# Altium schematic sheet main.SchDoc(main)
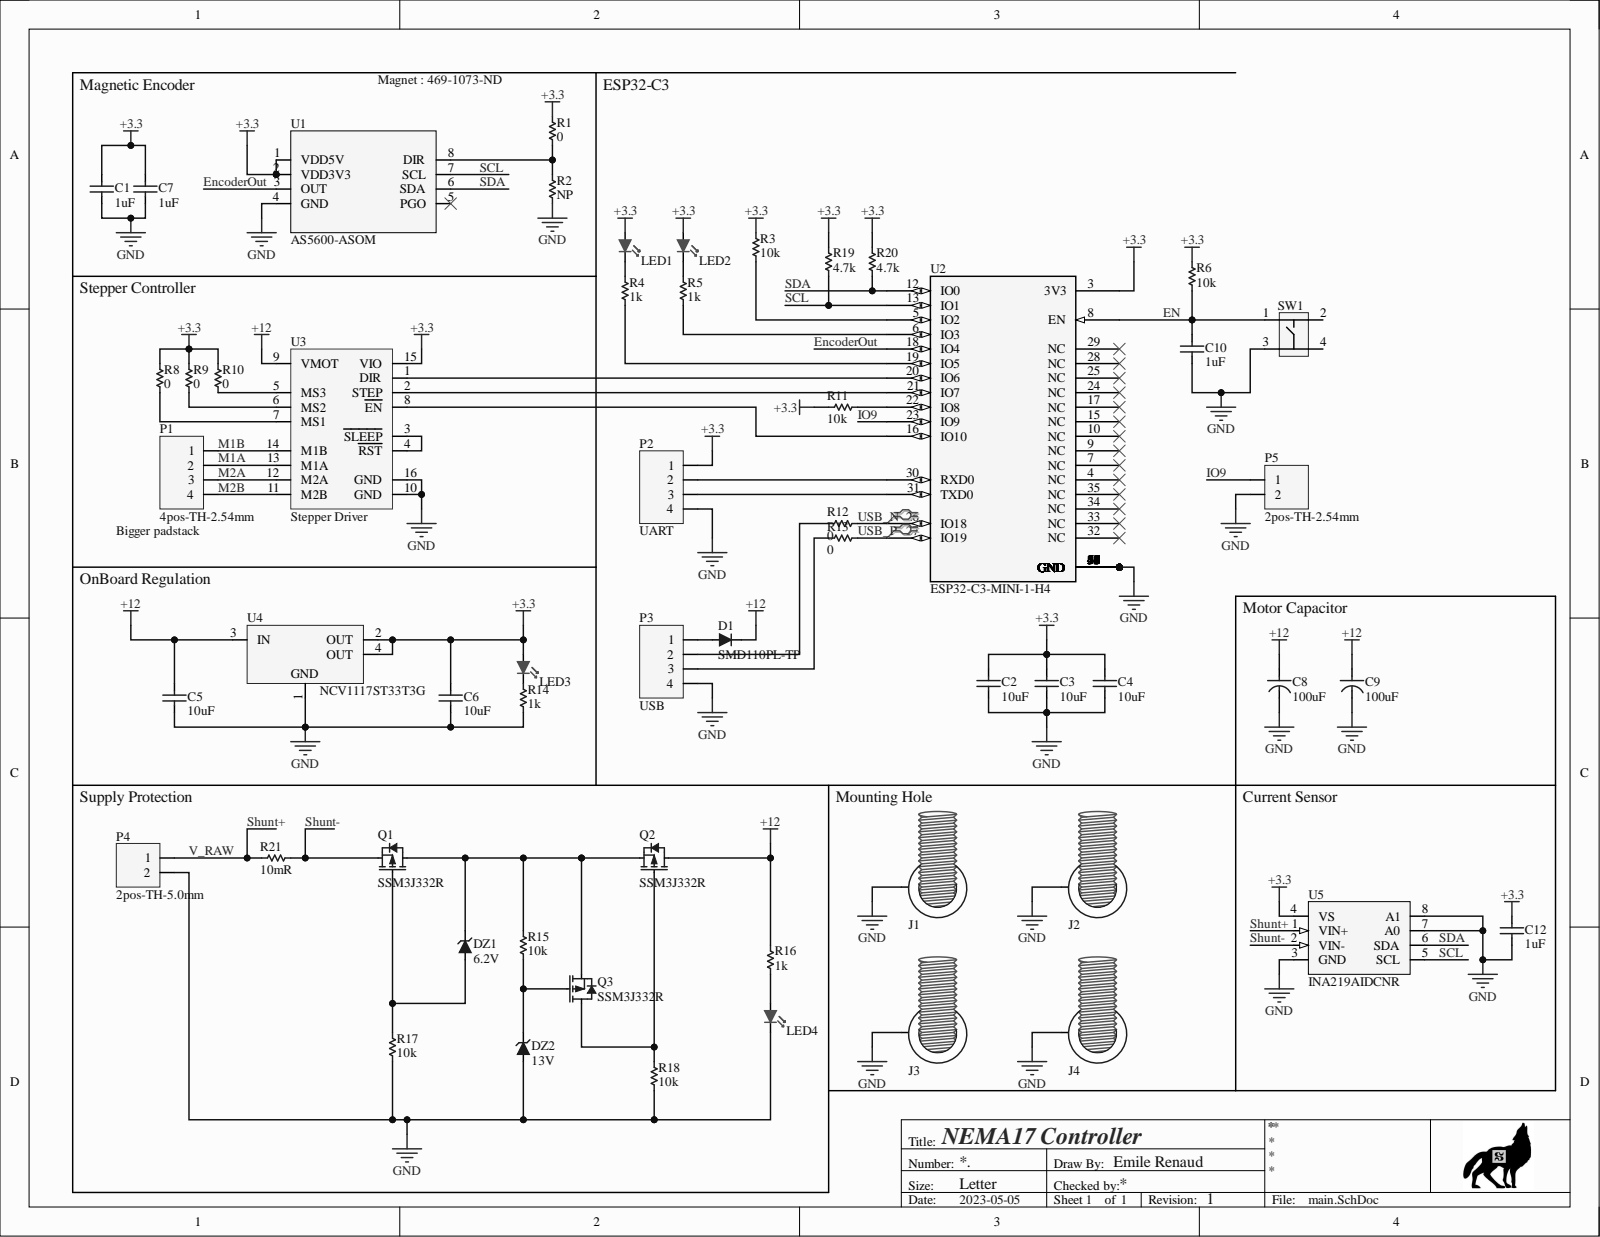
[diagram p0: main.SchDoc]

## Parts
C1  value 1uF  [CAPACITOR-0603]  (hidden comment: Capacitor-0603-1uF-25V-X5R)
C2  value 10uF  [CAPACITOR-0805]  (hidden comment: Capacitor-0805-10uF-35V-X5R)
C3  value 10uF  [CAPACITOR-0805]  (hidden comment: Capacitor-0805-10uF-35V-X5R)
C4  value 10uF  [CAPACITOR-0805]  (hidden comment: Capacitor-0805-10uF-35V-X5R)
C5  value 10uF  [CAPACITOR-0805]  (hidden comment: Capacitor-0805-10uF-35V-X5R)
C6  value 10uF  [CAPACITOR-0805]  (hidden comment: Capacitor-0805-10uF-35V-X5R)
C7  value 1uF  [CAPACITOR-0603]  (hidden comment: Capacitor-0603-1uF-25V-X5R)
C8  value 100uF  [CAPACITOR-Aluminium-5x11mm-2mmPitch]  (hidden comment: CAP ALUM 100UF 20% 25V RADIAL)
C9  value 100uF  [CAPACITOR-Aluminium-5x11mm-2mmPitch]  (hidden comment: CAP ALUM 100UF 20% 25V RADIAL)
C10  value 1uF  [CAPACITOR-0603]  (hidden comment: Capacitor-0603-1uF-25V-X5R)
C12  value 1uF  [CAPACITOR-0603]  (hidden comment: Capacitor-0603-1uF-25V-X5R)
D1  SMD110PL-TP  [SOD-123F]
DZ1  Volt: 6.2V  [SOD-123]  (hidden comment: BZT52C6V2-TP)
DZ2  Volt: 13V  [SOD-123]  (hidden comment: BZT52C13-TP)
J1  hole  [HOLE-3.40MM-M3-8MMEYELET]  (value not drawn)  (no BOM)
J2  hole  [HOLE-3.40MM-M3-8MMEYELET]  (value not drawn)  (no BOM)
J3  hole  [HOLE-3.40MM-M3-8MMEYELET]  (value not drawn)  (no BOM)
J4  hole  [HOLE-3.40MM-M3-8MMEYELET]  (value not drawn)  (no BOM)
LED1  B1911USD-20D000114U1930  [LED-0603-2PIN]  (value not drawn)
LED2  B1911USD-20D000114U1930  [LED-0603-2PIN]  (value not drawn)
LED3  B1911USD-20D000114U1930  [LED-0603-2PIN]  (value not drawn)
LED4  B1911USD-20D000114U1930  [LED-0603-2PIN]  (value not drawn)
P1  4pos-TH-2.54mm  [HDR1X4-W]
P2  UART  [HDR1X4]
P3  USB  [HDR1X4]
P4  2pos-TH-5.0mm  [Weco 2]
P5  2pos-TH-2.54mm  [HDR1X2]
Q1  SSM3J332R  [SOT-23-3]
Q2  SSM3J332R  [SOT-23-3]
Q3  SSM3J332R  [SOT-23-3]
R1  value 0  [Resistor-0603]  (hidden comment: SMT resistor)
R2  value NP  [Resistor-0603]  (hidden comment: SMT resistor)
R3  value 10k  [Resistor-0603]  (hidden comment: SMT resistor)
R4  value 1k  [Resistor-0603]  (hidden comment: SMT resistor)
R5  value 1k  [Resistor-0603]  (hidden comment: SMT resistor)
R6  value 10k  [Resistor-0603]  (hidden comment: SMT resistor)
R8  value 0  [Resistor-0603]  (hidden comment: SMT resistor)
R9  value 0  [Resistor-0603]  (hidden comment: SMT resistor)
R10  value 0  [Resistor-0603]  (hidden comment: SMT resistor)
R11  value 10k  [Resistor-0603]  (hidden comment: SMT resistor)
R12  value 0  [Resistor-0603]  (hidden comment: SMT resistor)
R13  value 0  [Resistor-0603]  (hidden comment: SMT resistor)
R14  value 1k  [Resistor-0603]  (hidden comment: SMT resistor)
R15  value 10k  [Resistor-0603]  (hidden comment: SMT resistor)
R16  value 1k  [Resistor-0603]  (hidden comment: SMT resistor)
R17  value 10k  [Resistor-0603]  (hidden comment: SMT resistor)
R18  value 10k  [Resistor-0603]  (hidden comment: SMT resistor)
R19  value 4.7k  [Resistor-0603]  (hidden comment: SMT resistor)
R20  value 4.7k  [Resistor-0603]  (hidden comment: SMT resistor)
R21  value 10mR  [RESISTOR-1206]  (hidden comment: SMT resistor)
SW1  PTS815 SJM 250 SMTR LFS  [SW4_PTS815 SJM 250 SMTR LFS_CNK]  (value not drawn)
U1  AS5600-ASOM  [AS5600L-ASOM_AMS]
U2  ESP32-C3-MINI-1-H4  [ESP32-C3-MINI-1_EXP]
U3  Stepper Driver  [A4899]
U4  NCV1117ST33T3G  [SOT-223-3/TO-261-3S]
U5  INA219AIDCNR  [SOT-23-8]

## Nets
+3.3: C1.2 C2.2 C3.2 C4.2 C6.2 C7.2 C12.2 LED1.2 LED2.2 LED3.2 P2.1 R1.2 R3.2 R6.2 R8.2 R9.2 R10.2 R11.2 R19.2 R20.2 U1.1(VDD5V) U1.2(VDD3V3) U2.3(3V3) U3.15(VIO) U4.2(OUT) U4.4(OUT) U5.4(VS)
+12: C5.2 C8.1 C9.1 D1.2 Q2.3 R16.2 U3.9(VMOT) U4.3(IN)
GND: C1.1 C2.1 C3.1 C4.1 C5.1 C6.1 C7.1 C8.2 C9.2 C10.1 C12.1 DZ2.1 J1.1 J2.1 J3.1 J4.1 LED4.1 P2.4 P3.4 P4.2 P5.2 R2.1 R14.1 R17.1 R18.1 SW1.3 U1.4(GND) U2.1(GND) U2.2 U2.11 U2.14 U2.36 U2.37 U2.38 U2.39 U2.40 U2.41 U2.42 U2.43 U2.44 U2.45 U2.46 U2.47 U2.48 U2.49 U2.50 U2.51 U2.52 U2.53 U2.54 U2.55 U2.56 U2.57 U2.58 U2.59 U2.60 U2.61 U3.10(GND) U3.16(GND) U4.1 U5.3(GND) U5.7(A0) U5.8(A1)
EN: C10.2 R6.1 SW1.1 U2.8(EN)
EncoderOut: U1.3(OUT) U2.18(IO4)
IO9: P5.1 U2.23(IO9)
M1A: P1.2 U3.13(M1A)
M1B: P1.1 U3.14(M1B)
M2A: P1.3 U3.12(M2A)
M2B: P1.4 U3.11(M2B)
SCL: R19.1 U1.7(SCL) U2.13(IO1) U5.5(SCL)
SDA: R20.1 U1.6(SDA) U2.12(IO0) U5.6(SDA)
Shunt+: P4.1 R21.1 U5.1(VIN+)
Shunt-: Q1.2 R21.2 U5.2(VIN-)
USB_N: R12.2 U2.26(IO18)
USB_P: R13.2 U2.27(IO19)
NetD1_1: D1.1 P3.1
NetDZ1_1: DZ1.1 Q1.1 R17.2
NetDZ1_2: DZ1.2 Q1.3 Q2.2 Q3.2 R15.2
NetDZ2_2: DZ2.2 Q3.1 R15.1
NetLED1_1: LED1.1 R4.2
NetLED2_1: LED2.1 R5.2
NetLED3_1: LED3.1 R14.2
NetLED4_2: LED4.2 R16.1
NetP2_2: P2.2 U2.30(RXD0)
NetP2_3: P2.3 U2.31(TXD0)
NetP3_2: P3.2 R12.1
NetP3_3: P3.3 R13.1
NetQ2_1: Q2.1 Q3.3 R18.2
NetR1_1: R1.1 R2.2 U1.8(DIR)
NetR3_1: R3.1 U2.5(IO2)
NetR4_1: R4.1 U2.19(IO5)
NetR5_1: R5.1 U2.6(IO3)
NetR8_1: R8.1 U3.7(MS1)
NetR9_1: R9.1 U3.6(MS2)
NetR10_1: R10.1 U3.5(MS3)
NetR11_1: R11.1 U2.22(IO8)
NetU2_16: U2.16(IO10) U3.8(EN)
NetU2_20: U2.20(IO6) U3.1(DIR)
NetU2_21: U2.21(IO7) U3.2(STEP)
NetU3_3: U3.3(SLEEP) U3.4(RST)

Not connected: SW1 2 4; U1 5(PGO); U2 4(NC) 7(NC) 9(NC) 10(NC) 15(NC) 17(NC) 24(NC) 25(NC) 28(NC) 29(NC) 32(NC) 33(NC) 34(NC) 35(NC)

## Library data of the parts
C1 C7 C10 C12 = Capacitor-0603-1uF-25V-X5R: Precision: X5R-10%; Volt: 25V; Component Kind: Standard; Description: Capacitor-0603-1uF-25V-X5R; Library Name: Capacitors.SchLib; Mounted: Yes; Package / Case: Metric 1608, EIA 0603; Supplier 1: Digi-Key; Supplier 2: Digi-Key; Supplier 3: Digi-Key; Supplier 4: Digi-Key; Supplier Part Number 1: 1276-1859-2-ND; Supplier Part Number 2: 1276-1942-2-ND
C2-C6 = Capacitor-0805-10uF-35V-X5R: Precision: X5R-10%; Volt: 35V; Component Kind: Standard; Description: Capacitor-0805-10uF-35V-X5R; Library Name: Capacitors.SchLib; Mounted: Yes; Package / Case: Metric 2012 , EIA 0805; Supplier 1: Digi-Key; Supplier 2: Digi-Key; Supplier 3: Digi-Key; Supplier 4: Digi-Key; Supplier Part Number 1: 490-10515-1-ND
C8 C9 = Capacitor-100uF-25V: Component Kind: Standard; Description: CAP ALUM 100UF 20% 25V RADIAL; Library Name: Capacitors-Electrolytic.SchLib; Mounted: Yes; Precision: 20%; Supplier 1: Digi-Key; Supplier 2: Digi-Key; Supplier 3: Digi-Key; Supplier 4: Digi-Key; Supplier Part Number 1: 399-6102-ND; Volt: 25V
D1 = SMD110PL-TP: DIODE SCHOTTKY 100V 1A SOD123FL; Component Kind: Standard; Library Name: diodes.SchLib; Mounted: Yes; Package / Case: SOD123FL; Supplier 1: Digi-Key; Supplier Part Number 1: SMD110PL-TPMSTR-ND; Volt: 100V
DZ1 = BZT52C6V2-TP: Zener Diode 6.2 V 200 mW ±6% Surface Mount SOD-123; Grade: None; Watt: 200mW; Component Kind: Standard; Library Name: diodes-zener.SchLib; Mounted: Yes; Package / Case: SOD-123; Precision: 6%; Supplier 1: Digi-Key; Supplier Part Number 1: BZT52C13-TPMSCT-ND
DZ2 = BZT52C13-TP: Zener Diode 13 V 200 mW ±6% Surface Mount SOD-123; Grade: None; Watt: 200mW; Component Kind: Standard; Library Name: diodes-zener.SchLib; Mounted: Yes; Package / Case: SOD-123; Precision: 6%; Supplier 1: Digi-Key; Supplier Part Number 1: BZT52C13-TPMSCT-ND
J1-J4 = Hole 3.40mm M3-Eyelet: Hole for M3 Bolt with 8mm pad; Library Name: JumpersAndHoles.SchLib; Mounted: N/A
LED1-LED4 = B1911USD-20D000114U1930: LED RED CLEAR 1608 SMD; Component Kind: Standard; Library Name: LEDs.SchLib; Mounted: Yes; Package / Case: 0603 (1608 Metric); Supplier 1: Digi-Key; Supplier Part Number 1: 3147-B1911USD-20D000114U1930CT-ND
P1-P3 = 4pos-TH-2.54mm: 4pos TH 2.54mm Header; Component Kind: Standard; Library Name: header.SchLib; Mounted: Yes; Package / Case: TH; Supplier 1: Digi-Key; Supplier Part Number 1: N/A; Volt: N/A
P4 = 2pos-TH-5.0mm: 2pos-TH-5.0mm Screw Terminal; Component Kind: Standard; Library Name: ScrewTerminal.SchLib; Mounted: Yes; Package / Case: TH; Supplier 1: Digi-Key; Supplier Part Number 1: N/A277-1667-ND; Volt: N/A
P5 = 2pos-TH-2.54mm: 2pos TH 2.54mm Header; Component Kind: Standard; Library Name: header.SchLib; Mounted: Yes; Package / Case: TH; Supplier 1: Digi-Key; Supplier Part Number 1: N/A; Volt: N/A
Q1-Q3 = SSM3J332R: P-Channel 30 V 6A (Ta) 1W (Ta) Surface Mount SOT-23F; Volt: 30V; Component Kind: Standard; Current: 6A; Library Name: FET-P-Small.SchLib; Mounted: Yes; Package / Case: SOT-523; Supplier 1: Digi-Key; Supplier Part Number 1: SSM3J332RLFCT-ND
R1 R2 R8-R10 R12 R13 = Resistor0603-0.0: Precision: 1%; Watt: 0.1W; Component Kind: Standard; Description: Resistor0603-0.0; Library Name: Resistors.SchLib; Mounted: Yes; Package / Case: Metric 1608, EIA 0603; Supplier 1: Digi-Key; Supplier 2: Digi-Key; Supplier 3: Digi-Key; Supplier 4: Digi-Key; Supplier Part Number 1: RMCF0603ZT0R00TR-ND; Supplier Part Number 2: 1276-3510-2-ND; Supplier Part Number 3: YAG1307TR-ND; Supplier Part Number 4: 541-0.0GTR-ND
R3 R6 R11 R15 R17 R18 = Resistor0603-10k: Precision: 1%; Watt: 0.1W; Component Kind: Standard; Description: Resistor0603-10k; Library Name: Resistors.SchLib; Mounted: Yes; Package / Case: Metric 1608, EIA 0603; Supplier 1: Digi-Key; Supplier 2: Digi-Key; Supplier 3: Digi-Key; Supplier 4: Digi-Key; Supplier Part Number 1: 311-10.0KHRTR-ND; Supplier Part Number 2: RMCF0603FT10K0TR-ND
R4 R5 R14 R16 = Resistor0603-1k: Precision: 1%; Watt: 0.1W; Component Kind: Standard; Description: Resistor0603-1k; Library Name: Resistors.SchLib; Mounted: Yes; Package / Case: Metric 1608, EIA 0603; Supplier 1: Digi-Key; Supplier 2: Digi-Key; Supplier 3: Digi-Key; Supplier 4: Digi-Key; Supplier Part Number 1: RMCF0603FT1K00TR-ND; Supplier Part Number 2: 311-1.00KHRTR-ND; Supplier Part Number 3: AG1307TR-ND
R19 R20 = Resistor0603-4.7k: Precision: 1%; Watt: 0.1W; Component Kind: Standard; Description: Resistor0603-4.7k; Library Name: Resistors.SchLib; Mounted: Yes; Package / Case: Metric 1608, EIA 0603; Supplier 1: Digi-Key; Supplier 2: Digi-Key; Supplier 3: Digi-Key; Supplier 4: Digi-Key; Supplier Part Number 1: 311-4.70KHRTR-ND; Supplier Part Number 2: RMCF0603FT4K70TR-ND
R21 = Resistor1206-10mR: Precision: 1%; Watt: 0.5W; Component Kind: Standard; Description: Resistor1206-10mR; Library Name: Resistors.SchLib; Mounted: Yes; Package / Case: Metric 3216, EIA 1206; Supplier 1: Digi-Key; Supplier 2: Digi-Key; Supplier 3: Digi-Key; Supplier 4: Digi-Key; Supplier Part Number 1: CSR1206FT10L0CT-ND
SW1 = PTS815 SJM 250 SMTR LFS: TACT 4.2 X 3.2, 2.5 MM H, 180GF,; Current: 0.05A; Volt: 12V; Component Kind: Standard; Library Name: THTPushButtonSwitches.SchLib; Mounted: Yes; Supplier 1: Digi-Key; Supplier Part Number 1: CKN12215-1-ND
U1 = AS5600-ASOM: SENSOR ANGLE 360DEG SMD; Manufacturer_Part_Number: AS5600L-ASOM; Mfr_Name: ams OSRAM; Component Kind: Standard; Library Name: Encoder.SchLib; RefDes: RefDes; Type: DEV; Copyright: Copyright (C) 2023 Ultra Librarian. All rights reserved.
U2 = ESP32-C3-MINI-1-N4: Bluetooth, WiFi 802.11b/g/n, Bluetooth v5.0 Transceiver Module 2.412GHz ~ 2.484GHz PCB Trace Surface Mount; Mfr_Name: Espressif Systems; Component Kind: Standard; Datasheet: https://www.espressif.com/sites/default/files/documentation/esp32-c3-mini-1_datasheet_en.pdf; Library Name: Microcontrollers.SchLib; Manufacturer_Part_Number: ESP32-C3-MINI-1-N4; RefDes: RefDes; Type: Type; Copyright: Copyright (C) 2023 Ultra Librarian. All rights reserved.
U3 = A4988: Stepper Driver PCB; Component Kind: Standard; Library Name: MotorDrivers.SchLib; Mounted: Yes; Package / Case: Custom; Supplier 1: Digi-Key
U4 = NCV1117ST33T3G: IC REG LINEAR 3.3V 1A SOT223; Component Kind: Standard; Library Name: PMIC-VoltageRegulators-LinearRegulators.SchLib; Mounted: Yes; Package / Case: SOT223; Supplier 1: Digi-Key; Supplier Part Number 1: NCV1117ST33T3GOSCT-ND
U5 = INA219: IC CURRENT MONITOR 1% SOT23-8; Component Kind: Standard; Library Name: PMIC-CurrentManagement.SchLib; Mounted: Yes; Package / Case: SOT-23-8; Supplier 1: Digi-Key; Supplier Part Number 1: 296-23770-1-ND
